# Altium schematic sheet Power.SchDoc(Power)
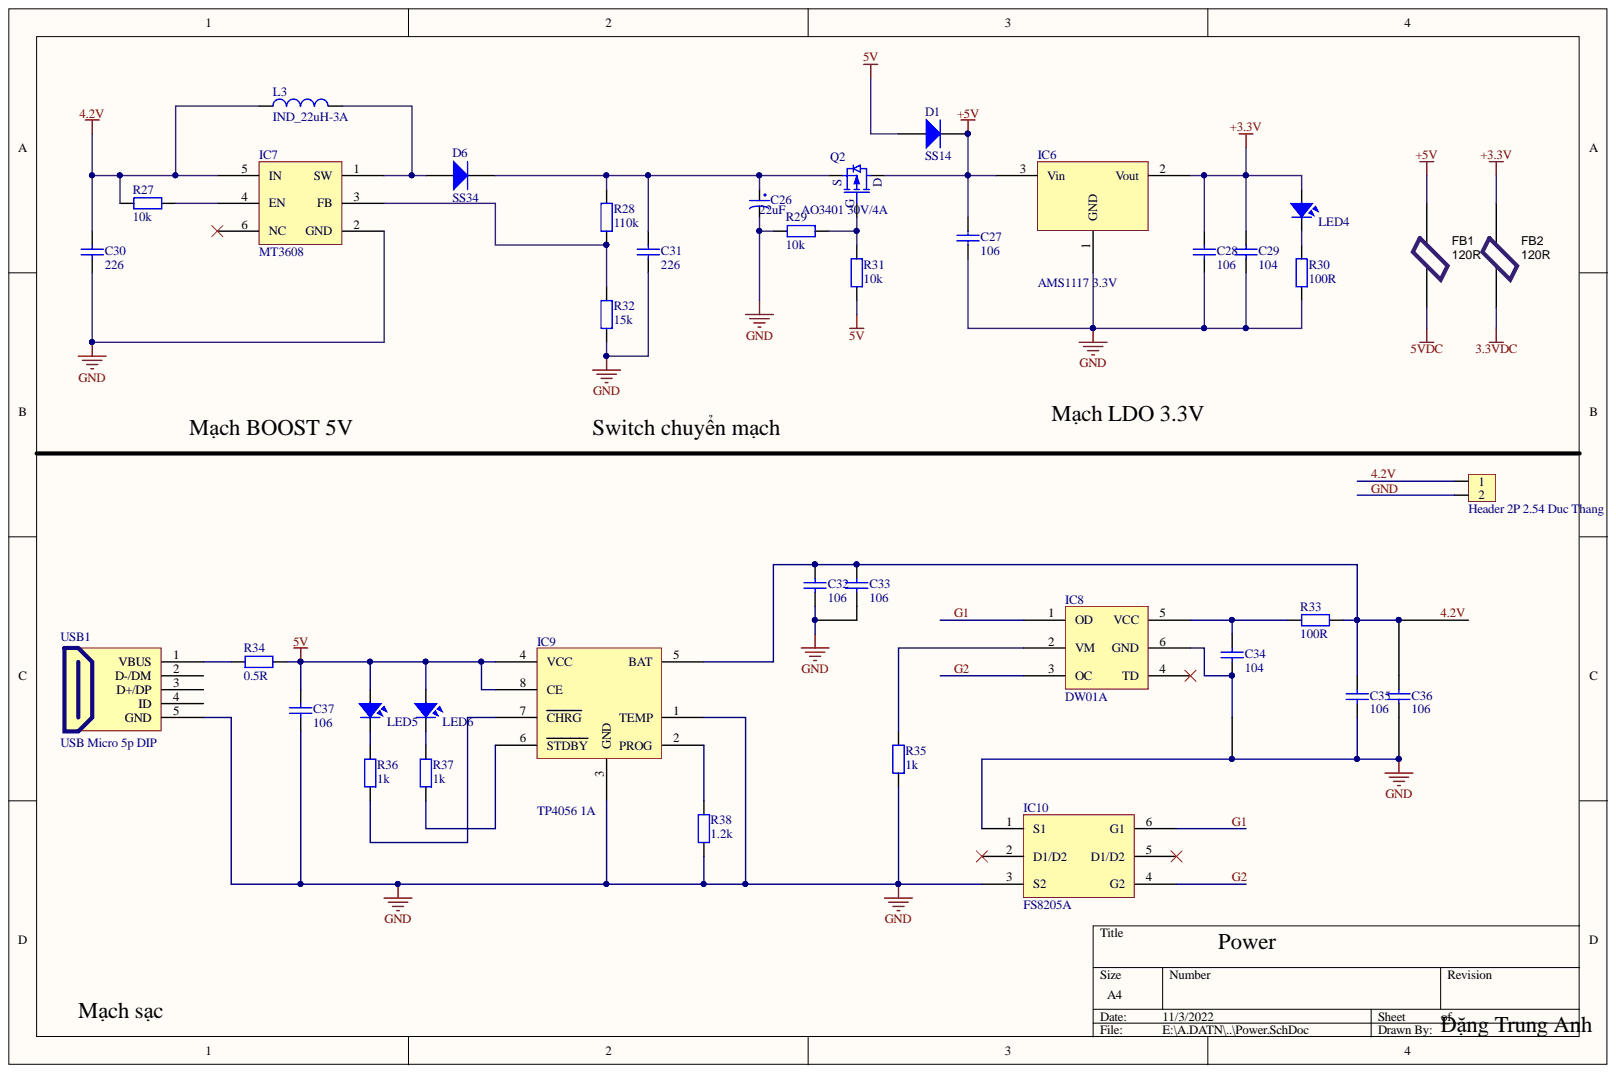
[diagram p0: Power.SchDoc]

## Parts
C26  22uF  [tu hoa 5mm]
C27  106  [Tu 0805]
C28  106  [Tu 0805]
C29  104  [tu 0805]
C30  226  [tu 0805]
C31  226  [tu 0805]
C32  106  [tu 0805]
C33  106  [Tu 0805]
C34  104  [tu 0805]
C35  106  [Tu 0805]
C36  106  [Tu 0805]
C37  106  [Tu 0805]
D1  SS14  [DIODE SMA]
D6  SS34  [DO_SMD_NEW]
FB1  120R  [INDC1608N]
FB2  120R  [INDC1608N]
H2  Header 2P 2.54 Duc Thang  [XH2.54M-2]
IC6  AMS1117 3.3V  [SOT223]
IC7  MT3608  [SOT23-6A]
IC8  DW01A  [SOT23127P600-8N]
IC9  TP4056 1A  [SOP8]
IC10  FS8205A  [SOT-23-6L]
L3  IND_22uH-3A  [IND_22_12X12_SMD]
LED4  LED SMD  [SM-LED-0805-Red]  (value not drawn)
LED5  LED SMD  [SM-LED-0805-Red]  (value not drawn)
LED6  LED SMD  [SM-LED-0805-Blue]  (value not drawn)
Q2  AO3401 30V/4A  [SOT23_3]
R27  10k  [tro smd 0805]
R28  110k  [tro smd 0805]
R29  10k  [tro smd 0805]
R30  100R  [tro smd 0805]
R31  10k  [tro smd 0805]
R32  15k  [tro smd 0805]
R33  100R  [tro smd 0805]
R34  0.5R  [tro smd 0805]
R35  1k  [tro smd 0805]
R36  1k  [tro smd 0805]
R37  1k  [tro smd 0805]
R38  1.2k  [tro smd 0805]
USB1  USB Micro 5p DIP  [USB Micro 5p DIP]

## Nets
3.3VDC: FB2.
5V: C37.1 D1.1 IC9.4(VCC) IC9.8(CE) LED5.1 LED6.1 R31.1 R34.2
5VDC: FB1.
+3.3V: C28.1 C29.1 FB2. IC6.2 LED4.1
+5V: C27.1 D1.2 FB1. IC6.3 Q2.3
4.2V: C30.1 C32.2 C33.1 C35.1 C36.1 H2.1 IC7.5(IN) IC9.5(BAT) L3.1 R27.2 R33.2
G1: IC8.1(OD) IC10.6(G1)
G2: IC8.3(OC) IC10.4(G2)
GND: C26.2 C27.2 C28.2 C29.2 C30.2 C31.2 C32.1 C33.2 C34.1 C35.2 C36.2 C37.2 H2.2 IC6.1 IC7.2(GND) IC8.6(GND) IC9.1(TEMP) IC9.3(GND) IC10.1(S1) IC10.3(S2) R29.1 R30.1 R32.1 R35.1 R38.1 USB1.5(GND)
NetC26_1: C26.1 C31.1 D6.2 Q2.2 R28.2
NetC34_2: C34.2 IC8.5(VCC) R33.1
NetD6_1: D6.1 IC7.1(SW) L3.2
NetIC7_3: IC7.3(FB) R28.1 R32.2
NetIC7_4: IC7.4(EN) R27.1
NetIC8_2: IC8.2(VM) R35.2
NetIC9_2: IC9.2(PROG) R38.2
NetIC9_6: IC9.6(STDBY) R37.1
NetIC9_7: IC9.7(CHRG) R36.1
NetLED4_2: LED4.2 R30.2
NetLED5_2: LED5.2 R36.2
NetLED6_2: LED6.2 R37.2
NetQ2_1: Q2.1 R29.2 R31.2
NetR34_1: R34.1 USB1.1(VBUS)

Not connected: IC7 6(NC); IC8 4(TD); IC10 2(D1/D2) 5(D1/D2); USB1 2(D-/DM) 3(D+/DP) 4(ID)

## Library data of the parts
C26 = Tu Hoa 22uF 35V 5x11: Cắm; Ten_Linh_Kien: Tụ Hóa 22uF 35V 5x11MM; So_Chan: 2; Ma_Hang: MH001350; Don_Vi: Con; Library Name: THU VIEN SCH.SchLib; Component Kind: Standard; Chung_Loai: Cắm
C27 C28 C32 C33 C35-C37 = Tu 0805 106 16V 10%: Dán; Ten_Linh_Kien: Tụ 0805 106-10uF 10% 16V; So_Chan: 2; Ma_Hang: MH004553; Don_Vi: Con; Component Kind: Standard; Chung_Loai: Dán
  C27 C28 C33 C35-C37: Library Name: THU VIEN SCH.SchLib
  C32: Library Name: Altium_Lib_by_KhueNguyenCreator.IntLib
C29 C34 = Tu 0805 104 50V 10%: Dán; Ten_Linh_Kien: Tụ 0805 104-100nF 10% 50V; So_Chan: 2; Ma_Hang: MH004551; Don_Vi: Con; Library Name: THU VIEN SCH.SchLib; Component Kind: Standard; Chung_Loai: Dán
C30 = Tu 0805 224 50V 10%: Dán; Ten_Linh_Kien: Tụ 0805 224-0.22uF 10% 50V; So_Chan: 2; Ma_Hang: MH004559; Don_Vi: Con; Component Kind: Standard; Chung_Loai: Dán
C31 = Tu 0805 226 16V 20%: Dán; Ten_Linh_Kien: Tụ 0805 224-0.22uF 10% 50V; So_Chan: 2; Ma_Hang: MH004559; Don_Vi: Con; Component Kind: Standard; Chung_Loai: Dán; Library Name: Altium_Lib_by_KhueNguyenCreator.IntLib
D1 = Diode SS14 ( 1N5819): Dán; Ten_Linh_Kien: Diode SS14 DO214 Schottky 1A 40V ( 1N5819 DO214); Ma_Hang: MH002211; Don_Vi: Con; Component Kind: Standard; Chung_Loai: Dán; Library Name: Altium_Lib_by_KhueNguyenCreator.IntLib
D6 = Diode SS34 ( 1N5822 ): Dán; Ten_Linh_Kien: Diode SS34 3A 40V SMA ( 1N5822 SS34A); Ma_Hang: MH002104; Don_Vi: Con; Library Name: THU VIEN SCH.SchLib; Component Kind: Standard; Chung_Loai: Dán
FB1 FB2 = FERRITE_120R_2.5A-0603: Ferrite bead 120 Ohm at 100 MHz 2A 0603 SMD; Manufacturer 1: Murata Electronics North America; Value: 120R @ 100 MHz; SCDType: source; Ratings: 2 A; 50 mO DC; Number of Lines: 1; Impedance @ Frequency: 120 Ohm @ 100MHz; Frequency - Test: 100kHz; Frequency - Self Resonant: 23MHz; Filter Type: Differential Mode - Single; DC Resistance (DCR): 172.9 mOhm Max; Current - Temperature Rise: 900mA; Supplier Part Number 1: 490-1037-1-ND; Supplier 1: Digi-Key; Size / Dimension: 0.063" L x 0.031" W (1.60mm x 0.80mm); Package / Case: 1210 (3225 Metric); Operating Temperature: -40°C ~ 105°C; Mounting Type: Surface Mount; Library Name: My library altium.IntLib; Height (Max): 0.037" (0.95mm); Current Rating: 2A; Current - Saturation: 900mA; Current: 900mA; ComponentLink2URL: http://search.murata.co.jp/Ceramy/image/img/PDF/ENG/L0110S0100BLM18P.pdf; ComponentLink2Description: http://search.murata.co.jp/Ceramy/image/img/PDF/ENG/L0110S0100BLM18P.pdf; ComponentLink1URL: http://www.yuden.co.jp/ut/product/pdf/wound02_e.pdf; ComponentLink1Description: http://www.yuden.co.jp/ut/product/pdf/wound02_e.pdf; Component Kind: Standard; Applications: Power Supplies
H2 = Header 2P 2.54 Duc Thang: Cắm; Ten_Linh_Kien: Header 2P XH2.54-2P Đực Thẳng; Ma_Hang: MH001283; Don_Vi: Con; Component Kind: Standard; Chung_Loai: Cắm; Library Name: Altium_Lib_by_KhueNguyenCreator.IntLib
IC7 = MT3608_Boost: Library Name: Integrated_Library.IntLib; Component Kind: Standard
L3 = IND_22uH-3A-30%-SMD_1: Fixed Inductors MSS1038 SMT Shielded 15 uH 20 % 3.8 A; Manufacturer Part Number: MSS1038-153MLC; Manufacturer: Bourns; Alternate Part Number-1: NA; Supplier Part Number-1: MSS1038-153MLC; Supplier-1: COILCRAFT SAMPLE; Component Kind: Standard; Case/Package: SMD; Library Name: Altium_Lib_by_KhueNguyenCreator.IntLib
LED4-LED6 = LED-SMD-0805: Component Kind: Standard; Library Name: syhaunguyen.IntLib
Q2 = AO3401: MOSFET P-CH 30V 4A SOT23; MPN: AO3415; MFR: Alpha & Omega Semiconductor Inc.; Value: 20V/4A; Solder: SMT; Library Name: BYTECH_HW_LIB_2019 (1).IntLib; Component Kind: Standard
R27 R29 R31 = Tro 0805 5% 10k: Dán; Ten_Linh_Kien: Trở 0805 5% 10k; So_Chan: 2; Ma_Hang: MH005239; Don_Vi: Con; Library Name: THU VIEN SCH.SchLib; Component Kind: Standard; Chung_Loai: Dán
R28 = Tro 0805 1% 110k: Dán; Ten_Linh_Kien: Trở 0805 1% 10k; So_Chan: 2; Ma_Hang: MH005473; Don_Vi: Con; Component Kind: Standard; Chung_Loai: Dán; Library Name: Altium_Lib_by_KhueNguyenCreator.IntLib
R30 R33 = Tro 0805 5% 100R: Dán; Ten_Linh_Kien: Trở 0805 5% 100R; So_Chan: 2; Ma_Hang: MH005251; Don_Vi: Con; Library Name: THU VIEN SCH.SchLib; Component Kind: Standard; Chung_Loai: Dán
R32 = Tro 0805 1% 15k: Dán; Ten_Linh_Kien: Trở 0805 1% 15k; So_Chan: 2; Ma_Hang: MH005476; Don_Vi: Con; Library Name: THU VIEN SCH.SchLib; Component Kind: Standard; Chung_Loai: Dán
R34 = Tro 0805 5% 0.5R: Dán; Ten_Linh_Kien: Trở 0805 5% 0.5R; So_Chan: 2; Ma_Hang: MH005303; Don_Vi: Con; Library Name: THU VIEN SCH.SchLib; Component Kind: Standard; Chung_Loai: Dán
R35-R37 = Tro 0805 5% 1k: Dán; Ten_Linh_Kien: Trở 0805 5% 1k; So_Chan: 2; Ma_Hang: MH005234; Don_Vi: Con; Library Name: THU VIEN SCH.SchLib; Component Kind: Standard; Chung_Loai: Dán
R38 = Tro 0805 5% 1.2k: Dán; Ten_Linh_Kien: Trở 0805 5% 1.2k; So_Chan: 2; Ma_Hang: MH005231; Don_Vi: Con; Library Name: THU VIEN SCH.SchLib; Component Kind: Standard; Chung_Loai: Dán
USB1 = USB Micro 5p DIP: USB Type B; Ten: MicroUSB MK5P DIP; Ma_Hang: MH005145; Component Kind: Standard; Library Name: Altium_Lib_by_KhueNguyenCreator.IntLib
IC6 = AMS1117 3.3V: Library Name: Integrated_Library.IntLib; Component Kind: Standard
IC8 = DW01A: Library Name: Integrated_Library.IntLib; Component Kind: Standard
IC9 = TP4056 1A: Library Name: Integrated_Library.IntLib; Component Kind: Standard
IC10 = FS8205A: Library Name: Integrated_Library.IntLib; Component Kind: Standard
